# Altium schematic sheet Interface.SchDoc
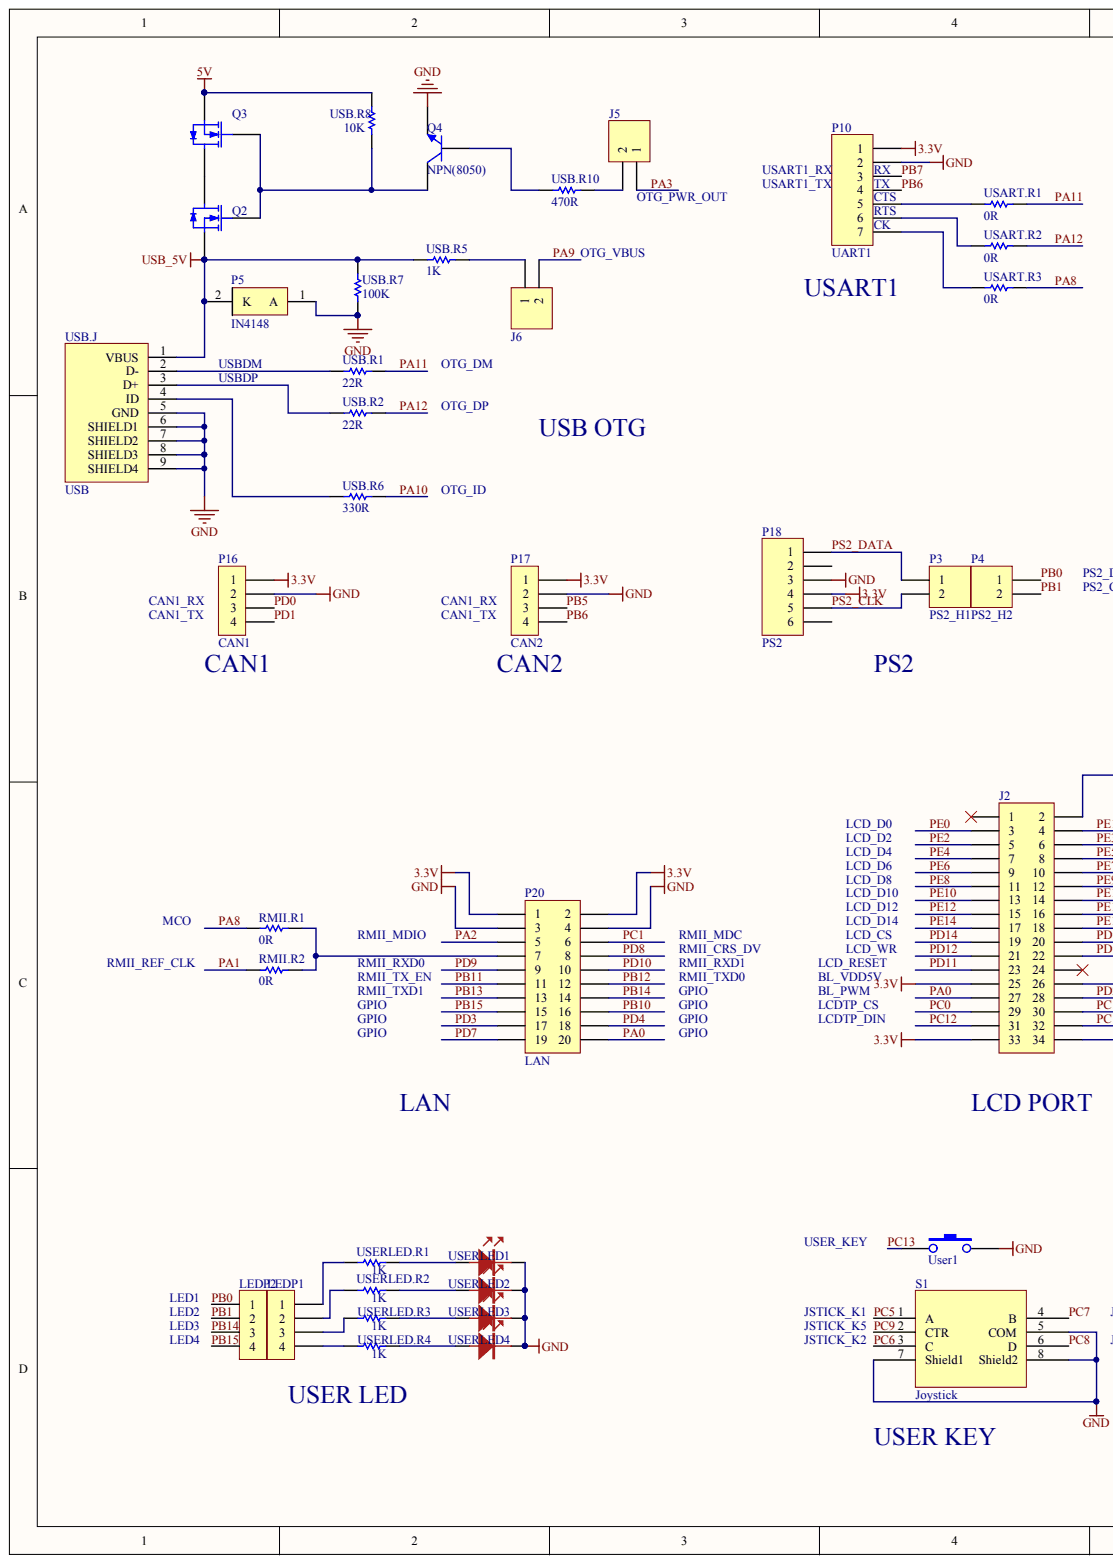
[diagram p0: Interface.SchDoc, left]
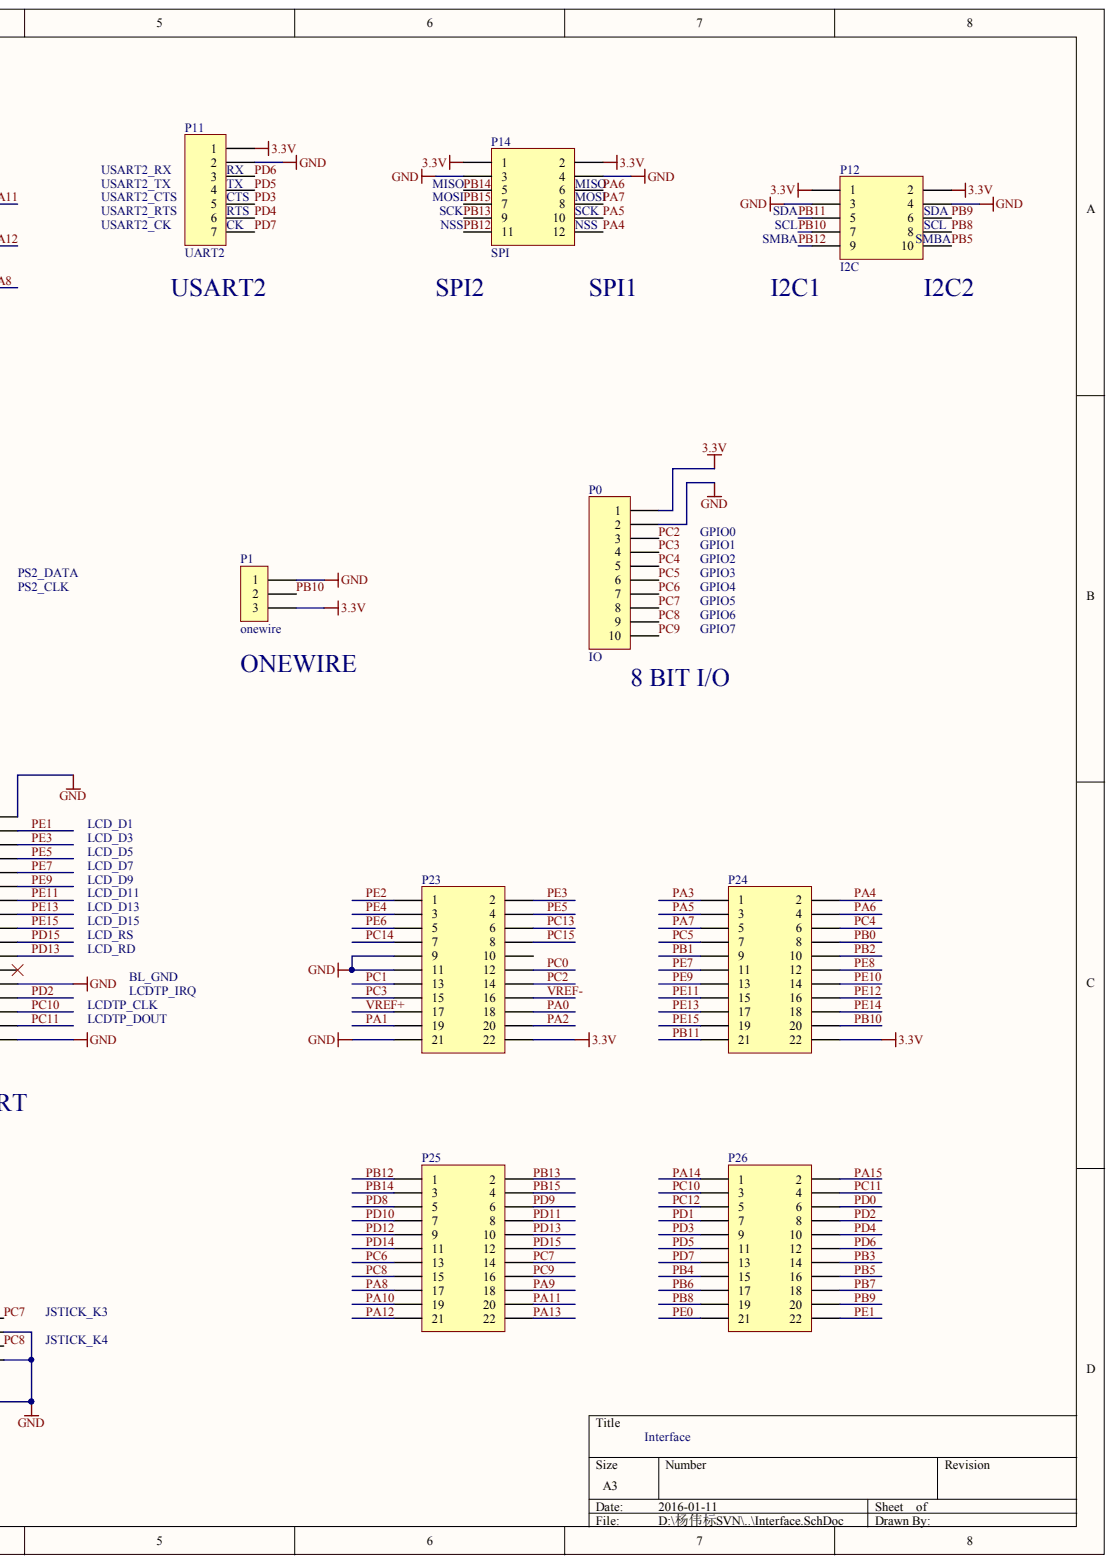
[diagram p1: Interface.SchDoc, right]

## Parts
J2  Header 17X2  [DIP17X2]  (value not drawn)
J5  (no value)  [SIP2]
J6  (no value)  [SIP2]
LEDP1  (no value)  [SIP4]
LEDP2  (no value)  [SIP4]
P0  IO  [SIP10_LyingMother]
P1  onewire  [SIP3]
P3  PS2_H1  [SIP2]
P4  PS2_H2  [SIP2]
P5  IN4148  [INXXXX]
P10  UART1  [SIP7_LyingMother]
P11  UART2  [SIP7_LyingMother]
P12  I2C  [SIP5_LyingMother X2]
P14  SPI  [SIP6_LyingMother X2]
P16  CAN1  [SIP4_LyingMother]
P17  CAN2  [SIP4_LyingMother]
P18  PS2  [PS2]
P20  LAN  [CONL10X2]
P23  (no value)  [SIP11x2]
P24  (no value)  [SIP11x2]
P25  (no value)  [SIP11x2]
P26  (no value)  [SIP11x2]
Q2  SI2302  [SOT-23]  (value not drawn)
Q3  SI2302  [SOT-23]  (value not drawn)
Q4  NPN(8050)  [SOT-23]
RMII.R1  0R  [0603]
RMII.R2  0R  [0603]
S1  Joystick  [JOYSTICK2]
USART.R1  0R  [0603]
USART.R2  0R  [0603]
USART.R3  0R  [0603]
USB.J  USB  [USB2]
USB.R1  22R  [0603]
USB.R2  22R  [0603]
USB.R5  1K  [0603]
USB.R6  330R  [0603]
USB.R7  100K  [0603]
USB.R8  10K  [0603]
USB.R10  470R  [0603]
User1  (no value)  [KEY]
USERLED1  LED_0805  [0805_LED]  (value not drawn)
USERLED2  LED_0805  [0805_LED]  (value not drawn)
USERLED3  LED_0805  [0805_LED]  (value not drawn)
USERLED4  LED_0805  [0805_LED]  (value not drawn)
USERLED.R1  1K  [0603]
USERLED.R2  1K  [0603]
USERLED.R3  1K  [0603]
USERLED.R4  1K  [0603]

## Nets
3.3V: J2.25 J2.33 P0.1 P1.3 P10.1 P11.1 P12.1 P12.2 P14.1 P14.2 P16.1 P17.1 P18.4 P20.1 P20.2 P23.22 P24.22
5V: Q3.2 USB.R8.1
GND: J2.2 J2.26 J2.34 P0.2 P1.1 P5.1 P10.2 P11.2 P12.3 P12.4 P14.3 P14.4 P16.2 P17.2 P18.3 P20.3 P20.4 P23.9 P23.11 P23.21 Q4.3 S1.5(COM) S1.7(Shield1) S1.8(Shield2) USB.J.5(GND) USB.J.6(SHIELD1) USB.J.7(SHIELD2) USB.J.8(SHIELD3) USB.J.9(SHIELD4) USB.R7.2 User1.2 USERLED1.2 USERLED2.2 USERLED3.2 USERLED4.2
USB_5V: P5.2 Q2.2 USB.J.1(VBUS) USB.R5.2 USB.R7.1
PA0: J2.27 P20.20 P23.18
PA1: P23.19 RMII.R2.1
PA2: P20.5 P23.20
PA3: J5.1 P24.1
PA4: P14.12 P24.2
PA5: P14.10 P24.3
PA6: P14.6 P24.4
PA7: P14.8 P24.5
PA8: P25.17 RMII.R1.1 USART.R3.2
PA9: J6.2 P25.18
PA10: P25.19 USB.R6.2
PA11: P25.20 USART.R1.2 USB.R1.2
PA12: P25.21 USART.R2.2 USB.R2.2
PA13: P25.22
PA14: P26.1
PA15: P26.2
PB0: LEDP2.1 P4.1 P24.8
PB1: LEDP2.2 P4.2 P24.9
PB2: P24.10
PB3: P26.14
PB4: P26.15
PB5: P12.10 P17.3 P26.16
PB6: P10.4 P17.4 P26.17
PB7: P10.3 P26.18
PB8: P12.8 P26.19
PB9: P12.6 P26.20
PB10: P1.2 P12.7 P20.16 P24.20
PB11: P12.5 P20.11 P24.21
PB12: P12.9 P14.11 P20.12 P25.1
PB13: P14.9 P20.13 P25.2
PB14: LEDP2.3 P14.5 P20.14 P25.3
PB15: LEDP2.4 P14.7 P20.15 P25.4
PC0: J2.29 P23.12
PC1: P20.6 P23.13
PC2: P0.3 P23.14
PC3: P0.4 P23.15
PC4: P0.5 P24.6
PC5: P0.6 P24.7 S1.1(A)
PC6: P0.7 P25.13 S1.3(C)
PC7: P0.8 P25.14 S1.4(B)
PC8: P0.9 P25.15 S1.6(D)
PC9: P0.10 P25.16 S1.2(CTR)
PC10: J2.30 P26.3
PC11: J2.32 P26.4
PC12: J2.31 P26.5
PC13: P23.6 User1.1
PC14: P23.7
PC15: P23.8
PD0: P16.3 P26.6
PD1: P16.4 P26.7
PD2: J2.28 P26.8
PD3: P11.5 P20.17 P26.9
PD4: P11.6 P20.18 P26.10
PD5: P11.4 P26.11
PD6: P11.3 P26.12
PD7: P11.7 P20.19 P26.13
PD8: P20.8 P25.5
PD9: P20.9 P25.6
PD10: P20.10 P25.7
PD11: J2.23 P25.8
PD12: J2.21 P25.9
PD13: J2.22 P25.10
PD14: J2.19 P25.11
PD15: J2.20 P25.12
PE0: J2.3 P26.21
PE1: J2.4 P26.22
PE2: J2.5 P23.1
PE3: J2.6 P23.2
PE4: J2.7 P23.3
PE5: J2.8 P23.4
PE6: J2.9 P23.5
PE7: J2.10 P24.11
PE8: J2.11 P24.12
PE9: J2.12 P24.13
PE10: J2.13 P24.14
PE11: J2.14 P24.15
PE12: J2.15 P24.16
PE13: J2.16 P24.17
PE14: J2.17 P24.18
PE15: J2.18 P24.19
PS2_CLK: P3.2 P18.5
PS2_DATA: P3.1 P18.1
VREF+: P23.17
VREF-: P23.16
NetJ5_2: J5.2 USB.R10.1
NetJ6_1: J6.1 USB.R5.1
NetLEDP1_1: LEDP1.1 USERLED.R1.2
NetLEDP1_2: LEDP1.2 USERLED.R2.2
NetLEDP1_3: LEDP1.3 USERLED.R3.2
NetLEDP1_4: LEDP1.4 USERLED.R4.2
NetP10_5: P10.5 USART.R1.1
NetP10_6: P10.6 USART.R2.1
NetP10_7: P10.7 USART.R3.1
NetP20_7: P20.7 RMII.R1.2 RMII.R2.2
NetQ2_1: Q2.1 Q3.1 Q4.2 USB.R8.2
NetQ2_3: Q2.3 Q3.3
NetQ4_1: Q4.1 USB.R10.2
NetUSB.J_2: USB.J.2(D-) USB.R1.1
NetUSB.J_3: USB.J.3(D+) USB.R2.1
NetUSB.J_4: USB.J.4(ID) USB.R6.1
NetUSERLED1_1: USERLED1.1 USERLED.R1.1
NetUSERLED2_1: USERLED2.1 USERLED.R2.1
NetUSERLED3_1: USERLED3.1 USERLED.R3.1
NetUSERLED4_1: USERLED4.1 USERLED.R4.1

Not connected: J2 1 24; P18 2 6; P23 10

## Library data of the parts
J2 = Header 17X2: Header, 16-Pin, Dual row; Component Kind: Standard; LatestRevisionDate: 17-Jul-2002; LatestRevisionNote: Re-released for DXP Platform.; Library Name: zz.SchLib; Publisher: Altium Limited
J5 J6 P3 P4 = Header 2: Header, 2-Pin; Component Kind: Standard; LatestRevisionDate: 17-Jul-2002; LatestRevisionNote: Re-released for DXP Platform.; Library Name: zz.SchLib; Publisher: Altium Limited
LEDP1 LEDP2 P16 P17 = Header 4: Header, 4-Pin; Component Kind: Standard; LatestRevisionDate: 17-Jul-2002; LatestRevisionNote: Re-released for DXP Platform.; Library Name: zz.SchLib; Publisher: Altium Limited
P0 = Header 10: Header, 10-Pin; Component Kind: Standard; Library Name: zz.SchLib
P1 = Header 3: Header, 3-Pin; Component Kind: Standard; Library Name: zz.SchLib
P5 = INXXXX: Component Kind: Standard; Library Name: zz.SchLib
P10 P11 = Header 7: Header, 7-Pin; Component Kind: Standard; Library Name: zz.SchLib
P12 = Header 5X2: Component Kind: Standard; Library Name: zz.SchLib
P14 = Header 6X2: Component Kind: Standard; Library Name: zz.SchLib
P18 = Header 6: Header, 6-Pin; Component Kind: Standard; LatestRevisionDate: 17-Jul-2002; LatestRevisionNote: Re-released for DXP Platform.; Library Name: zz.SchLib; Publisher: Altium Limited
P20 = Header_10X2: Component Kind: Standard; Library Name: Schlib1.SchLib
P23-P26 = Header 11X2: Component Kind: Standard; Library Name: zz.SchLib
Q2 Q3 = MOSFET-N: N-Channel MOSFET; Component Kind: Standard; Library Name: zz.SchLib
Q4 = NPN: Component Kind: Standard; Library Name: zz.SchLib
RMII.R1 RMII.R2 USART.R1-USART.R3 USB.R1 USB.R2 USB.R6-USB.R8 USB.R10 = Res1: Resistor; Value: 1K; Component Kind: Standard; LatestRevisionDate: 17-Jul-2002; LatestRevisionNote: Re-released for DXP Platform.; Library Name: zz.SchLib; PackageReference: AXIAL-0.3; Published: 8-Jun-2000; Publisher: Altium Limited
USB.R5 USERLED.R1-USERLED.R4 = Res1: Resistor; Component Kind: Standard; LatestRevisionDate: 17-Jul-2002; LatestRevisionNote: Re-released for DXP Platform.; Library Name: zz.SchLib; PackageReference: AXIAL-0.3; Published: 8-Jun-2000; Value: 1K; Publisher: Altium Limited
User1 = KEY: Component Kind: Standard; Library Name: zz.SchLib
S1 = Joystick: Component Kind: Standard; Library Name: zz.SchLib
USB.J = USB: Component Kind: Standard; Library Name: zz.SchLib
USERLED1-USERLED4 = LED_0805: Component Kind: Standard; Library Name: zz.SchLib
